# Altium schematic sheet 4_sensores.SchDoc(4_sensores)
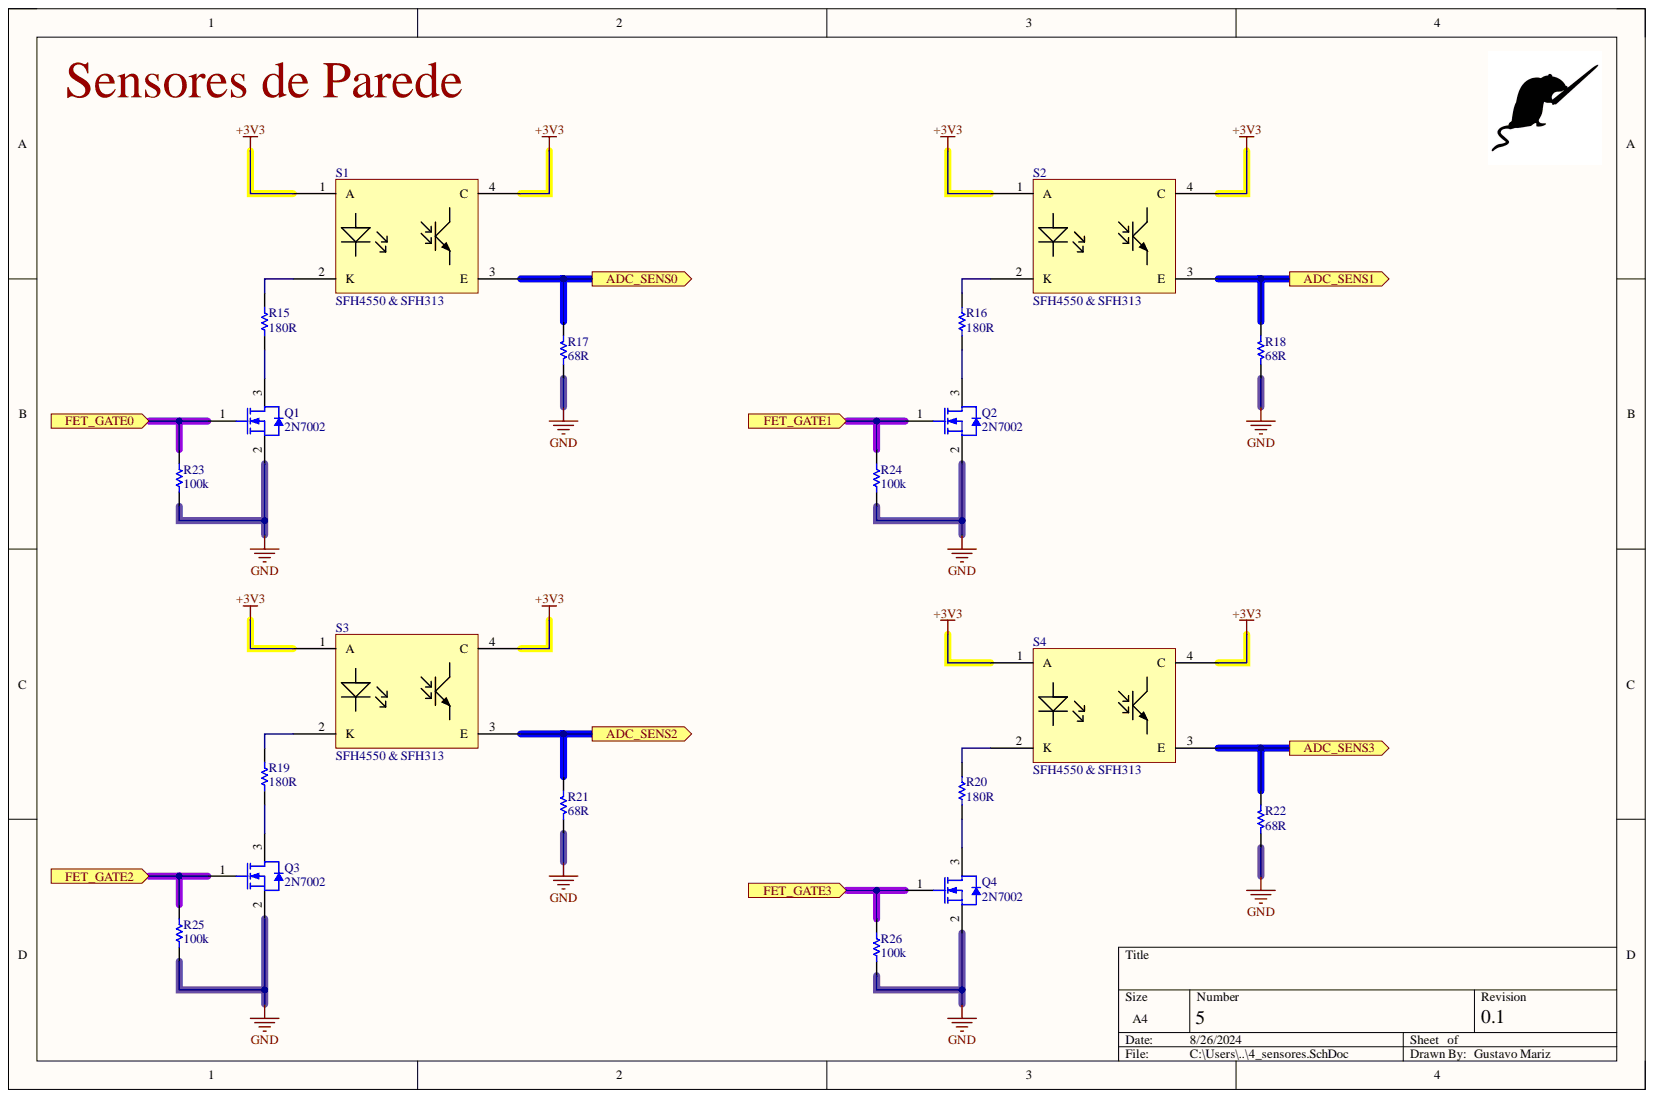
[diagram p0: 4_sensores.SchDoc]

## Parts
Q1  2N7002  [STM-SOT-23-3_V]
Q2  2N7002  [STM-SOT-23-3_V]
Q3  2N7002  [STM-SOT-23-3_V]
Q4  2N7002  [STM-SOT-23-3_V]
R15  value 180R  [RES 0805]  (hidden comment: RESISTOR)
R16  value 180R  [RES 0805]  (hidden comment: RESISTOR)
R17  value 68R  [RES 0805]  (hidden comment: RESISTOR)
R18  value 68R  [RES 0805]  (hidden comment: RESISTOR)
R19  value 180R  [RES 0805]  (hidden comment: RESISTOR)
R20  value 180R  [RES 0805]  (hidden comment: RESISTOR)
R21  value 68R  [RES 0805]  (hidden comment: RESISTOR)
R22  value 68R  [RES 0805]  (hidden comment: RESISTOR)
R23  value 100k  [RES 0603]  (hidden comment: RESISTOR)
R24  value 100k  [RES 0603]  (hidden comment: RESISTOR)
R25  value 100k  [RES 0603]  (hidden comment: RESISTOR)
R26  value 100k  [RES 0603]  (hidden comment: RESISTOR)
S1  SFH4550 & SFH313  [umouse-sensor-fp]
S2  SFH4550 & SFH313  [umouse-sensor-fp]
S3  SFH4550 & SFH313  [umouse-sensor-fp]
S4  SFH4550 & SFH313  [umouse-sensor-fp]

## Ports
ADC_SENS0, ADC_SENS1, ADC_SENS2, ADC_SENS3, FET_GATE0, FET_GATE1, FET_GATE2, FET_GATE3

## Nets
+3V3: S1.1(A) S1.4(C) S2.1(A) S2.4(C) S3.1(A) S3.4(C) S4.1(A) S4.4(C)
GND: Q1.2 Q2.2 Q3.2 Q4.2 R17.1 R18.1 R21.1 R22.1 R23.1 R24.1 R25.1 R26.1
ADC_SENS0: R17.2 S1.3(E) ; port ADC_SENS0
ADC_SENS1: R18.2 S2.3(E) ; port ADC_SENS1
ADC_SENS2: R21.2 S3.3(E) ; port ADC_SENS2
ADC_SENS3: R22.2 S4.3(E) ; port ADC_SENS3
FET_GATE0: Q1.1 R23.2 ; port FET_GATE0
FET_GATE1: Q2.1 R24.2 ; port FET_GATE1
FET_GATE2: Q3.1 R25.2 ; port FET_GATE2
FET_GATE3: Q4.1 R26.2 ; port FET_GATE3
NetQ1_3: Q1.3 R15.1
NetQ2_3: Q2.3 R16.1
NetQ3_3: Q3.3 R19.1
NetQ4_3: Q4.3 R20.1
NetR15_2: R15.2 S1.2(K)
NetR16_2: R16.2 S2.2(K)
NetR19_2: R19.2 S3.2(K)
NetR20_2: R20.2 S4.2(K)

## Library data of the parts
Q1-Q4 = CMP-0434-00001-1: N-channel 60 V, 1.8 Ohm, 0.35 A STripFET(TM) II Power MOSFET, SOT23; Manufacturer: STMicroelectronics; Component Kind: Standard; ComponentLink1Description: Manufacturer URL; ComponentLink1URL: http://www.st.com/; ComponentLink2Description: Datasheet; ComponentLink2URL: http://www.st.com/st-web-ui/static/active/en/resource/technical/document/datasheet/CD00005134.pdf; DatasheetVersion: Rev. 9, 11/2008; Library Name: Altium Content Vault; Mounting Technology: Surface Mount; PackageDescription: 3-Pin SOT23, 3.016 x 2.8 mm Body, 0.95 mm Pitch; PackageReference: SOT-23; PartNumber: 2N7002
R15-R26 = RESISTOR: Component Kind: Standard; Description: Resistor; Library Name: Biblioteca Trincabotz (1).IntLib
S1-S4 = SFH4550 & SFH313: Component Kind: Standard; Library Name: umouse-sensor.IntLib
